# Altium schematic sheet SCH.SchDoc
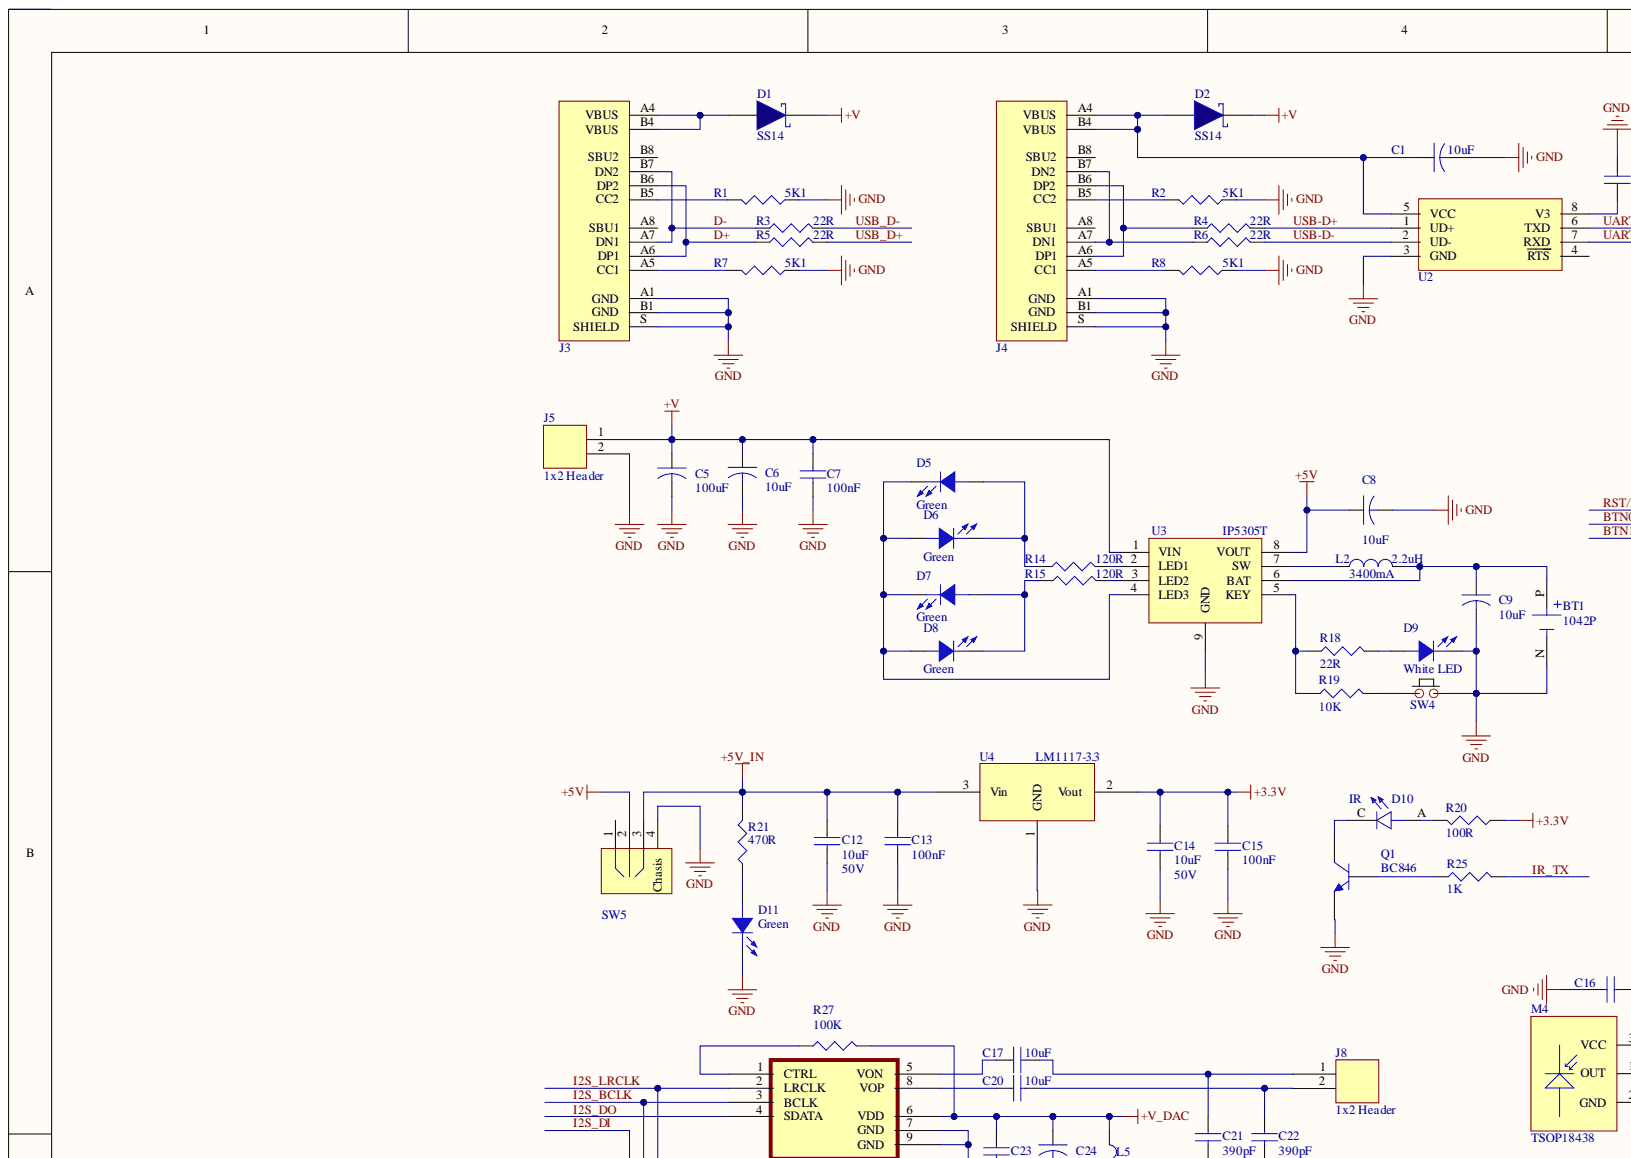
[diagram p0: SCH.SchDoc, top left]
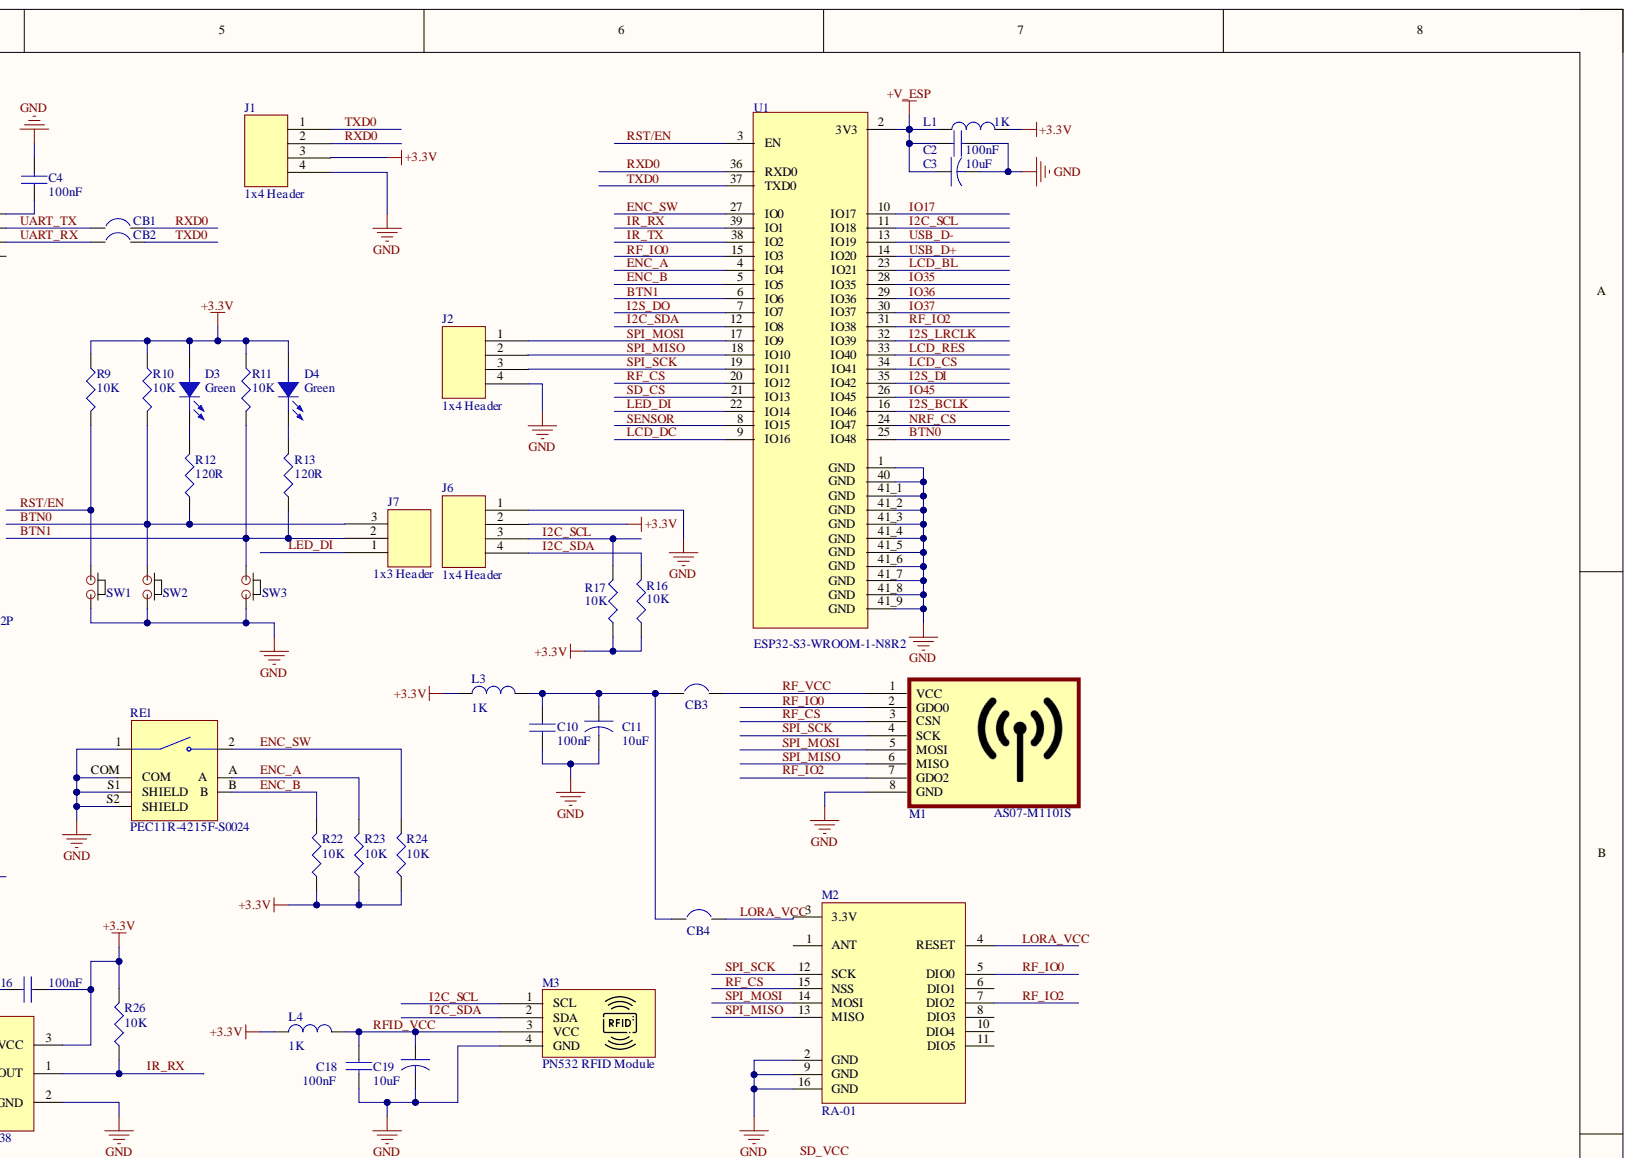
[diagram p1: SCH.SchDoc, top right]
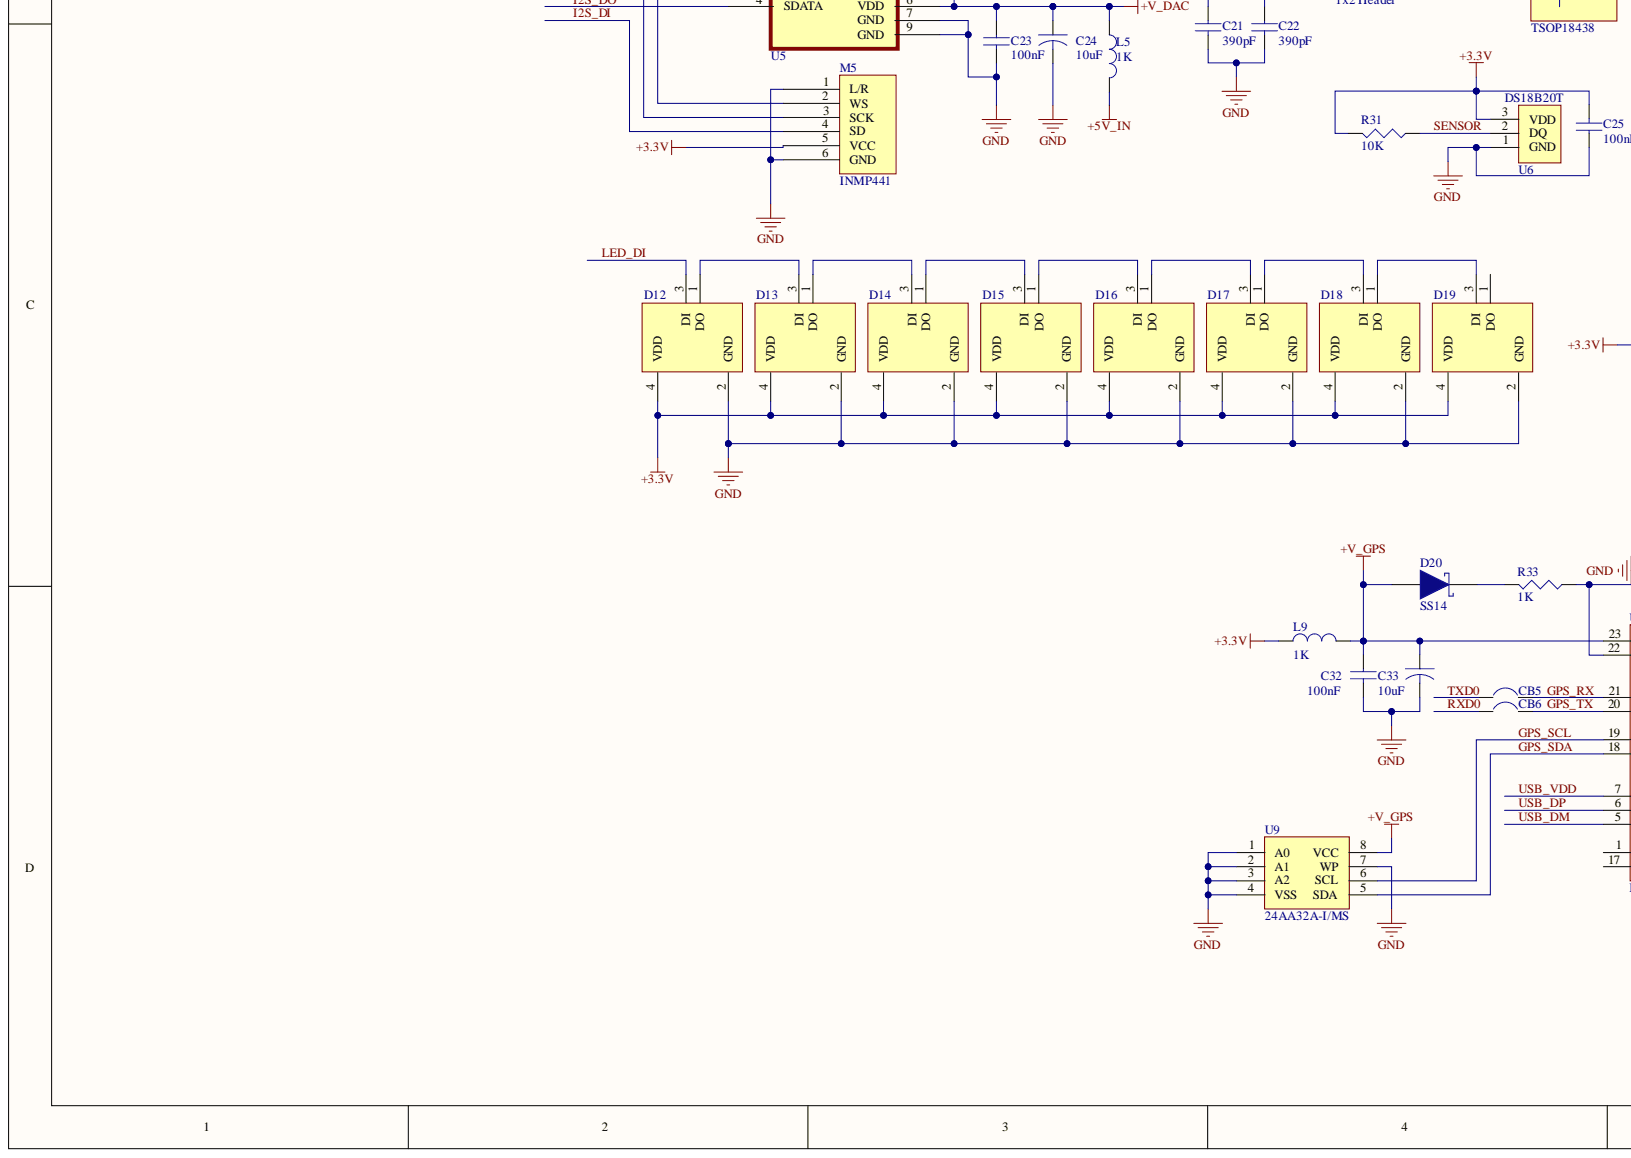
[diagram p2: SCH.SchDoc, bottom left]
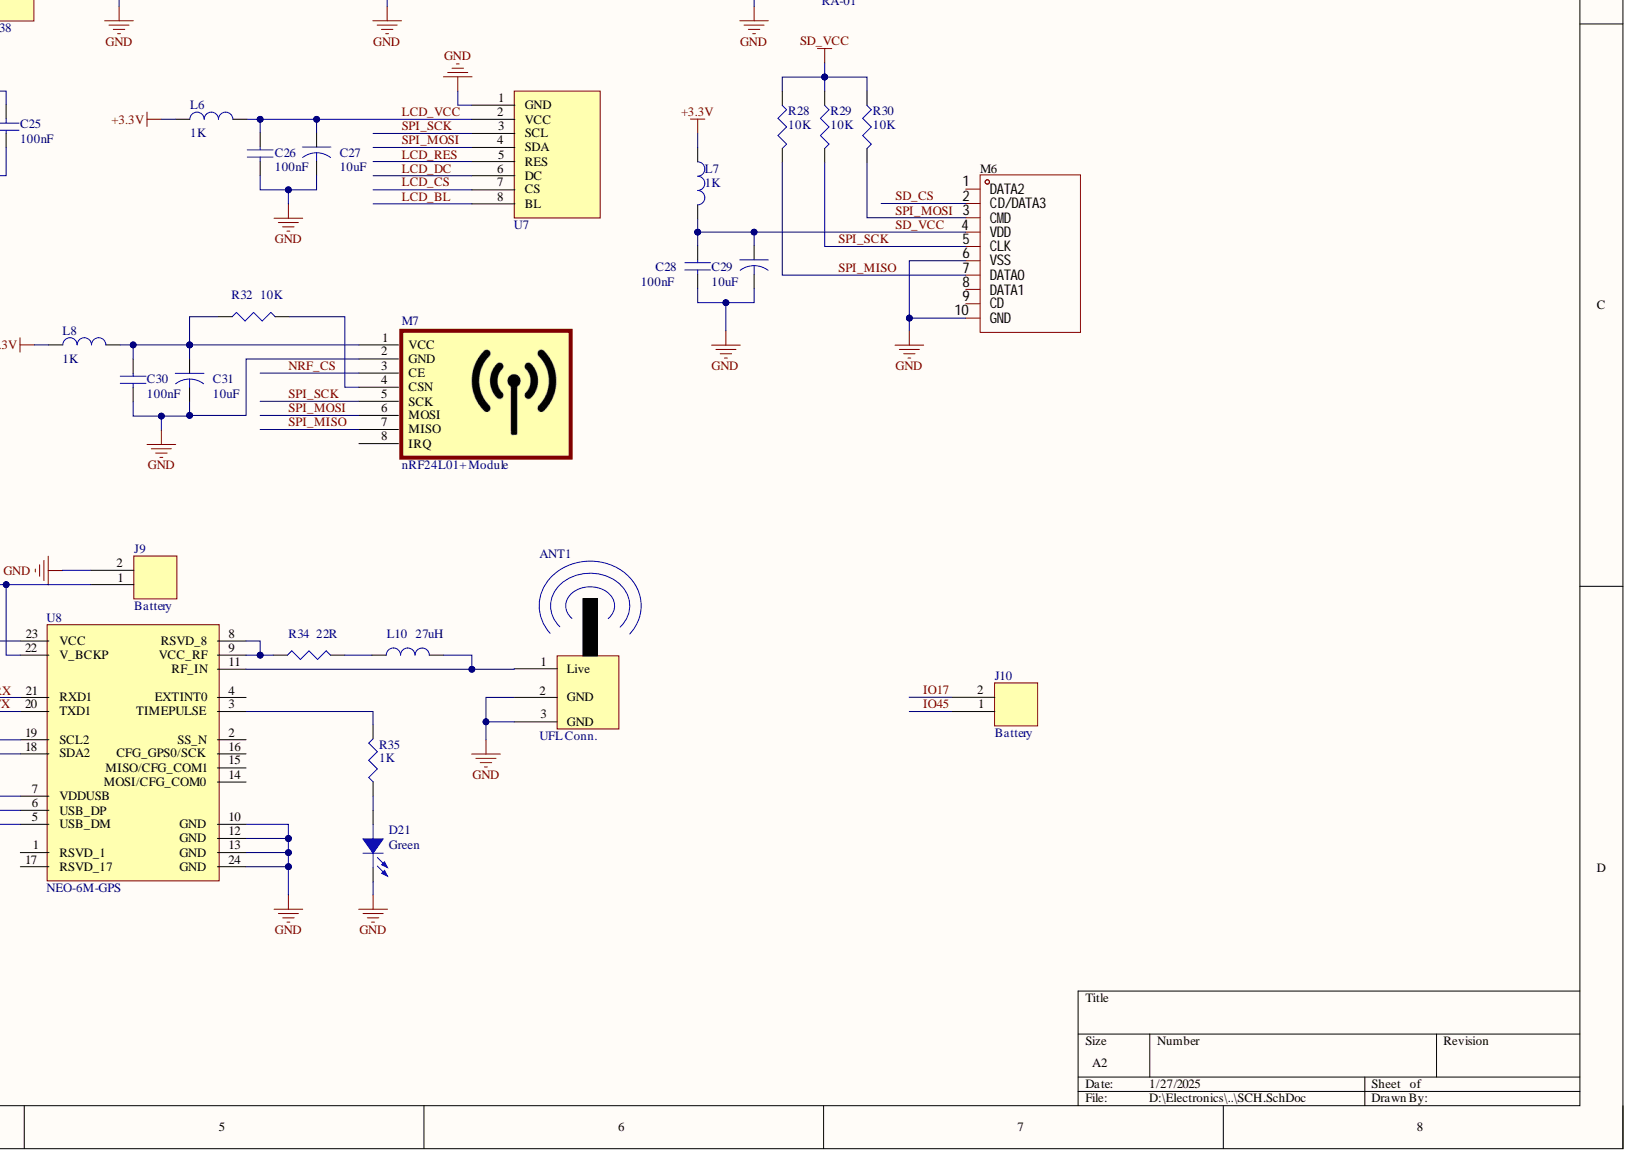
[diagram p3: SCH.SchDoc, bottom right]

## Parts
ANT1  value UFL Conn.  [UFL CONN]  (hidden comment: UFL Antenna)
BT1  1042P  [BAT_1042P_v2]
C1  value 10uF  [A Case]  (hidden comment: T491A106)
C2  value 100nF  [0805 SMD Ceramic Capacitor]  (hidden comment: C0805C104)
C3  value 10uF  [A Case]  (hidden comment: T491A106)
C4  value 100nF  [0805 SMD Ceramic Capacitor]  (hidden comment: C0805C104)
C5  value 100uF  [D Case]  (hidden comment: T491D107)
C6  value 10uF  [A Case]  (hidden comment: T491A106)
C7  value 100nF  [0805 SMD Ceramic Capacitor]  (hidden comment: C0805C104)
C8  value 10uF  [A Case]  (hidden comment: T491A106)
C9  value 10uF  [A Case]  (hidden comment: T491A106)
C10  value 100nF  [0805 SMD Ceramic Capacitor]  (hidden comment: C0805C104)
C11  value 10uF  [A Case]  (hidden comment: T491A106)
C12  value 10uF  Voltage: 50V  [1206 SMD Ceramic Capacitor]  (hidden comment: C1206C106)
C13  value 100nF  [0805 SMD Ceramic Capacitor]  (hidden comment: C0805C104)
C14  value 10uF  Voltage: 50V  [1206 SMD Ceramic Capacitor]  (hidden comment: C1206C106)
C15  value 100nF  [0805 SMD Ceramic Capacitor]  (hidden comment: C0805C104)
C16  value 100nF  [0805 SMD Ceramic Capacitor]  (hidden comment: C0805C104)
C17  value 10uF  [1206 SMD Ceramic Capacitor]  (hidden comment: C1206C106)
C18  value 100nF  [0805 SMD Ceramic Capacitor]  (hidden comment: C0805C104)
C19  value 10uF  [A Case]  (hidden comment: T491A106)
C20  value 10uF  [1206 SMD Ceramic Capacitor]  (hidden comment: C1206C106)
C21  value 390pF  [0805 SMD Ceramic Capacitor]  (hidden comment: C0805C391)
C22  value 390pF  [0805 SMD Ceramic Capacitor]  (hidden comment: C0805C391)
C23  value 100nF  [0805 SMD Ceramic Capacitor]  (hidden comment: C0805C104)
C24  value 10uF  [A Case]  (hidden comment: T491A106)
C25  value 100nF  [0805 SMD Ceramic Capacitor]  (hidden comment: C0805C104)
C26  value 100nF  [0805 SMD Ceramic Capacitor]  (hidden comment: C0805C104)
C27  value 10uF  [A Case]  (hidden comment: T491A106)
C28  value 100nF  [0805 SMD Ceramic Capacitor]  (hidden comment: C0805C104)
C29  value 10uF  [A Case]  (hidden comment: T491A106)
C30  value 100nF  [0805 SMD Ceramic Capacitor]  (hidden comment: C0805C104)
C31  value 10uF  [A Case]  (hidden comment: T491A106)
C32  value 100nF  [0805 SMD Ceramic Capacitor]  (hidden comment: C0805C104)
C33  value 10uF  [A Case]  (hidden comment: T491A106)
CB1  CircuitBraker  [CircuitBraker]  (value not drawn)
CB2  CircuitBraker  [CircuitBraker]  (value not drawn)
CB3  CircuitBraker  [CircuitBraker]  (value not drawn)
CB4  CircuitBraker  [CircuitBraker]  (value not drawn)
CB5  CircuitBraker  [CircuitBraker]  (value not drawn)
CB6  CircuitBraker  [CircuitBraker]  (value not drawn)
D1  SS14  [SMA]
D2  SS14  [SMA]
D3  value Green  [0805 Green LED]  (hidden comment: 0805 Green LED)
D4  value Green  [0805 Green LED]  (hidden comment: 0805 Green LED)
D5  value Green  [0805 Green LED]  (hidden comment: 0805 Green LED)
D6  value Green  [0805 Green LED]  (hidden comment: 0805 Green LED)
D7  value Green  [0805 Green LED]  (hidden comment: 0805 Green LED)
D8  value Green  [0805 Green LED]  (hidden comment: 0805 Green LED)
D9  value White LED  [5MM DIP WHITE LED]  (hidden comment: 5MM White LED)
D10  TSHG6200  [LEDRD254W55D595H900]  (value not drawn)
D11  value Green  [0805 Green LED]  (hidden comment: 0805 Green LED)
D12  WS2812C-2020  [LED_WS2812C-2020]  (value not drawn)
D13  WS2812C-2020  [LED_WS2812C-2020]  (value not drawn)
D14  WS2812C-2020  [LED_WS2812C-2020]  (value not drawn)
D15  WS2812C-2020  [LED_WS2812C-2020]  (value not drawn)
D16  WS2812C-2020  [LED_WS2812C-2020]  (value not drawn)
D17  WS2812C-2020  [LED_WS2812C-2020]  (value not drawn)
D18  WS2812C-2020  [LED_WS2812C-2020]  (value not drawn)
D19  WS2812C-2020  [LED_WS2812C-2020]  (value not drawn)
D20  SS14  [SMA]
D21  value Green  [0805 Green LED]  (hidden comment: 0805 Green LED)
J1  value 1x4 Header  [1x4 4 Pin Header]  (hidden comment: 1x4 4 Pin Header)
J2  value 1x4 Header  [1x4 4 Pin Header]  (hidden comment: 1x4 4 Pin Header)
J3  TYPE-C-31-M-12  [HRO_TYPE-C-31-M-12]  (value not drawn)
J4  TYPE-C-31-M-12  [HRO_TYPE-C-31-M-12]  (value not drawn)
J5  value 1x2 Header  [1x2 2 Pin Header]  (hidden comment: 1x2 2 Pin Header)
J6  value 1x4 Header  [1x4 4 Pin Header]  (hidden comment: 1x4 4 Pin Header)
J7  value 1x3 Header  [1x3 3 Pin Header]  (hidden comment: 1x3 3 Pin Header)
J8  value 1x2 Header  [1x2 2 Pin Header]  (hidden comment: 1x2 2 Pin Header)
J9  value Battery  [1x2 2 Pin Header]  (hidden comment: 1x2 2 Pin Header)
J10  value Battery  [1x2 2 Pin Header]  (hidden comment: 1x2 2 Pin Header)
L1  value 1K  [0805 Inductor]  (hidden comment: 0805Ferrite)
L2  2.2uH_3400mA  value 2.2uH  Current: 3400mA  [SMNR4020]
L3  value 1K  [0805 Inductor]  (hidden comment: 0805Ferrite)
L4  value 1K  [0805 Inductor]  (hidden comment: 0805Ferrite)
L5  value 1K  [0805 Inductor]  (hidden comment: 0805Ferrite)
L6  value 1K  [0805 Inductor]  (hidden comment: 0805Ferrite)
L7  value 1K  [0805 Inductor]  (hidden comment: 0805Ferrite)
L8  value 1K  [0805 Inductor]  (hidden comment: 0805Ferrite)
L9  value 1K  [0805 Inductor]  (hidden comment: 0805Ferrite)
L10  value 27uH  [0805 Inductor]  (hidden comment: 0805Ferrite)
M1  AS07-M1101S  [AS07-M1101S]
M2  RA-01  [XCVR_RA-01H]
M3  value PN532 RFID Module  [PN532 RFID Module]  (hidden comment: RC522 RFID Module)
M4  TSOP18438  [TSOP18438]
M5  INMP441  [INMP441]
M6  XKTF-N02-G  [TF-SMD_XKTF-N02-G]  (value not drawn)
M7  value nRF24L01+ Module  [NRF24L01_Module]  (hidden comment: NRF24L01_SMD)
Q1  BC846  [SOT23]
R1  value 5K1  [0805 Resistor]  (hidden comment: 0805_5K1%1)
R2  value 5K1  [0805 Resistor]  (hidden comment: 0805_5K1%1)
R3  value 22R  [0805 Resistor]  (hidden comment: 0805_22R%1)
R4  value 22R  [0805 Resistor]  (hidden comment: 0805_22R%1)
R5  value 22R  [0805 Resistor]  (hidden comment: 0805_22R%1)
R6  value 22R  [0805 Resistor]  (hidden comment: 0805_22R%1)
R7  value 5K1  [0805 Resistor]  (hidden comment: 0805_5K1%1)
R8  value 5K1  [0805 Resistor]  (hidden comment: 0805_5K1%1)
R9  value 10K  [0805 Resistor]  (hidden comment: 0805_10K)
R10  value 10K  [0805 Resistor]  (hidden comment: 0805_10K)
R11  value 10K  [0805 Resistor]  (hidden comment: 0805_10K)
R12  value 120R  [0805 Resistor]  (hidden comment: 0805_120R)
R13  value 120R  [0805 Resistor]  (hidden comment: 0805_120R)
R14  value 120R  [0805 Resistor]  (hidden comment: 0805_120R)
R15  value 120R  [0805 Resistor]  (hidden comment: 0805_120R)
R16  value 10K  [0805 Resistor]  (hidden comment: 0805_10K)
R17  value 10K  [0805 Resistor]  (hidden comment: 0805_10K)
R18  value 22R  [0805 Resistor]  (hidden comment: 0805_22R%1)
R19  value 10K  [0805 Resistor]  (hidden comment: 0805_10K)
R20  value 100R  [0805 Resistor]  (hidden comment: 0805_100R%1)
R21  value 470R  [0805 Resistor]  (hidden comment: 0805_470R%1)
R22  value 10K  [0805 Resistor]  (hidden comment: 0805_10K)
R23  value 10K  [0805 Resistor]  (hidden comment: 0805_10K)
R24  value 10K  [0805 Resistor]  (hidden comment: 0805_10K)
R25  value 1K  [0805 Resistor]  (hidden comment: 0805_1K)
R26  value 10K  [0805 Resistor]  (hidden comment: 0805_10K)
R27  value 100K  [0805 Resistor]  (hidden comment: 0805_100K%1)
R28  value 10K  [0805 Resistor]  (hidden comment: 0805_10K)
R29  value 10K  [0805 Resistor]  (hidden comment: 0805_10K)
R30  value 10K  [0805 Resistor]  (hidden comment: 0805_10K)
R31  value 10K  [0805 Resistor]  (hidden comment: 0805_10K)
R32  value 10K  [0805 Resistor]  (hidden comment: 0805_10K)
R33  value 1K  [0805 Resistor]  (hidden comment: 0805_10K)
R34  value 22R  [0805 Resistor]  (hidden comment: 0805_22R%1)
R35  value 1K  [0805 Resistor]  (hidden comment: 0805_10K)
RE1  PEC11R-4215F-S0024  [XDCR_PEC11R-4215F-S0024]
SW1  2.5mm Tactile Push Button  [2.5mm Tactile Push Button]  (value not drawn)
SW2  2.5mm Tactile Push Button  [2.5mm Tactile Push Button]  (value not drawn)
SW3  2.5mm Tactile Push Button  [2.5mm Tactile Push Button]  (value not drawn)
SW4  2.5mm Tactile Push Button  [2.5mm Tactile Push Button]  (value not drawn)
SW5  MSK12C02G15-B  [MSK12C02G15-B]  (value not drawn)
U1  ESP32-S3-WROOM-1-N8R2  [XCVR_ESP32-S3-WROOM-1-N8R2]
U2  CH340N  [SOIC127P600X160-8N]  (value not drawn)
U3  IP5305T  [DDA8]
U4  LM1117-3.3  [SOT223]
U5  NS4168  [DDA8]  (value not drawn)
U6  DS18B20  value DS18B20T  [TO92]
U7  ST7789_1.9_170x320  [ST7789_1.9_170x320]  (value not drawn)
U8  NEO-6M-GPS  [XCVR_NEO-6M-GPS]
U9  24AA32A-I/MS  [SOP65P490X110-8N]

## Nets
+3.3V: C14.1 C15.1 C16.1 C25.2 D3.1 D4.1 D12.4(VDD) D13.4(VDD) D14.4(VDD) D15.4(VDD) D16.4(VDD) D17.4(VDD) D18.4(VDD) D19.4(VDD) J1.3 J6.2 L1.2 L3.1 L4.1 L6.1 L7.1 L8.1 L9.1 M4.3 M5.5(VCC) R9.1 R10.1 R11.1 R16.1 R17.1 R20.1 R22.1 R23.1 R24.1 R26.2 R31.2 U4.2 U6.3
+5V: C8.1 SW5.2 U3.8(VOUT)
+5V_IN: C12.1 C13.1 L5.2 R21.2 SW5.3 U4.3
+V: C5.1 C6.1 C7.1 D1.2 D2.2 J5.1 U3.1(VIN)
+V_DAC: C23.1 C24.1 L5.1 R27.2 U5.6(VDD)
+V_ESP: C2.1 C3.1 L1.1 U1.2(3V3)
+V_GPS: C32.1 C33.1 D20.1 L9.2 U8.23(VCC) U9.8(VCC)
GND: ANT1.2 ANT1.3 BT1.N C1.2 C2.2 C3.2 C4.2 C5.2 C6.2 C7.2 C8.2 C9.2 C10.2 C11.2 C12.2 C13.2 C14.2 C15.2 C16.2 C18.2 C19.2 C21.2 C22.2 C23.2 C24.2 C25.1 C26.2 C27.2 C28.2 C29.2 C30.2 C31.2 C32.2 C33.2 D9.C D11.2 D12.2(GND) D13.2(GND) D14.2(GND) D15.2(GND) D16.2(GND) D17.2(GND) D18.2(GND) D19.2(GND) D21.2 J1.4 J2.4 J3.A1(GND) J3.B1(GND) J3.S(SHIELD) J4.A1(GND) J4.B1(GND) J4.S(SHIELD) J5.2 J6.1 J9.2 M1.8(GND) M2.2(GND) M2.9(GND) M2.16(GND) M3.4(GND) M4.2 M5.1(L/R) M5.6(GND) M6.6(VSS) M6.10(GND) M7.2(GND) Q1.2 R1.1 R2.1 R7.1 R8.1 RE1.1 RE1.COM RE1.S1(SHIELD) RE1.S2(SHIELD) SW1.2 SW2.2 SW3.2 SW4.2 SW5.4(Chasis) U1.1(GND) U1.40(GND) U1.41_1(GND) U1.41_2(GND) U1.41_3(GND) U1.41_4(GND) U1.41_5(GND) U1.41_6(GND) U1.41_7(GND) U1.41_8(GND) U1.41_9(GND) U2.3(GND) U3.9(GND) U4.1 U5.7(GND) U5.9(GND) U6.1 U7.1(GND) U8.10(GND) U8.12(GND) U8.13(GND) U8.24(GND) U9.1(A0) U9.2(A1) U9.3(A2) U9.4(VSS) U9.7(WP)
BTN0: J7.3 R10.2 R12.1 SW2.1 U1.25(IO48)
BTN1: J7.2 R11.2 R13.1 SW3.1 U1.6(IO6)
D+: J3.A6(DP1) J3.B6(DP2) R5.1
D-: J3.A7(DN1) J3.B7(DN2) R3.1
ENC_A: R23.2 RE1.A U1.4(IO4)
ENC_B: R22.2 RE1.B U1.5(IO5)
ENC_SW: R24.2 RE1.2 U1.27(IO0)
GPS_RX: CB5.1 U8.21(RXD1)
GPS_SCL: U8.19(SCL2) U9.6(SCL)
GPS_SDA: U8.18(SDA2) U9.5(SDA)
GPS_TX: CB6.1 U8.20(TXD1)
I2C_SCL: J6.3 M3.1(SCL) R17.2 U1.11(IO18)
I2C_SDA: J6.4 M3.2(SDA) R16.2 U1.12(IO8)
I2S_BCLK: M5.3(SCK) U1.16(IO46) U5.3(BCLK)
I2S_DI: M5.4(SD) U1.35(IO42)
I2S_DO: U1.7(IO7) U5.4(SDATA)
I2S_LRCLK: M5.2(WS) U1.32(IO39) U5.2(LRCLK)
IO17: J10.2 U1.10(IO17)
IO35: U1.28(IO35)
IO36: U1.29(IO36)
IO37: U1.30(IO37)
IO45: J10.1 U1.26(IO45)
IR_RX: M4.1 R26.1 U1.39(IO1)
IR_TX: R25.2 U1.38(IO2)
LCD_BL: U1.23(IO21) U7.8(BL)
LCD_CS: U1.34(IO41) U7.7(CS)
LCD_DC: U1.9(IO16) U7.6(DC)
LCD_RES: U1.33(IO40) U7.5(RES)
LCD_VCC: C26.1 C27.1 L6.2 U7.2(VCC)
LED_DI: D12.3(DI) J7.1 U1.22(IO14)
LORA_VCC: CB4.2 M2.3(3.3V) M2.4(RESET)
NRF_CS: M7.3(CE) U1.24(IO47)
RF_CS: M1.3(CSN) M2.15(NSS) U1.20(IO12)
RF_IO0: M1.2(GDO0) M2.5(DIO0) U1.15(IO3)
RF_IO2: M1.7(GDO2) M2.7(DIO2) U1.31(IO38)
RF_VCC: CB3.2 M1.1(VCC)
RFID_VCC: C18.1 C19.1 L4.2 M3.3(VCC)
RST/EN: R9.2 SW1.1 U1.3(EN)
RXD0: CB1.2 CB6.2 J1.2 U1.36(RXD0)
SD_CS: M6.2(CD/DATA3) U1.21(IO13)
SD_VCC: C28.1 C29.1 L7.2 M6.4(VDD) R28.1 R29.1 R30.1
SENSOR: R31.1 U1.8(IO15) U6.2
SPI_MISO: J2.2 M1.6(MISO) M2.13(MISO) M6.7(DATA0) M7.7(MISO) R28.2 U1.18(IO10)
SPI_MOSI: J2.1 M1.5(MOSI) M2.14(MOSI) M6.3(CMD) M7.6(MOSI) R30.2 U1.17(IO9) U7.4(SDA)
SPI_SCK: J2.3 M1.4(SCK) M2.12(SCK) M6.5(CLK) M7.5(SCK) R29.2 U1.19(IO11) U7.3(SCL)
TXD0: CB2.2 CB5.2 J1.1 U1.37(TXD0)
UART_RX: CB2.1 U2.7(RXD)
UART_TX: CB1.1 U2.6(TXD)
USB-D+: R4.2 U2.1(UD+)
USB-D-: R6.2 U2.2(UD-)
USB_D+: R5.2 U1.14(IO20)
USB_D-: R3.2 U1.13(IO19)
USB_DM: U8.5(USB_DM)
USB_DP: U8.6(USB_DP)
USB_VDD: U8.7(VDDUSB)
NetANT1_1: ANT1.1 L10.2 U8.11(RF_IN)
NetBT1_P: BT1.P C9.1 L2.2 U3.6(BAT)
NetC1_1: C1.1 D2.1 J4.A4(VBUS) J4.B4(VBUS) U2.5(VCC)
NetC4_1: C4.1 U2.8(V3)
NetC10_1: C10.1 C11.1 CB3.1 CB4.1 L3.2
NetC17_1: C17.1 U5.5(VON)
NetC17_2: C17.2 C21.1 J8.1
NetC20_1: C20.1 U5.8(VOP)
NetC20_2: C20.2 C22.1 J8.2
NetC30_1: C30.1 C31.1 L8.2 M7.1(VCC) R32.1
NetD1_1: D1.1 J3.A4(VBUS) J3.B4(VBUS)
NetD3_2: D3.2 R12.2
NetD4_2: D4.2 R13.2
NetD5_1: D5.1 D6.2 R14.1
NetD5_2: D5.2 D6.1 D7.2 D8.1 U3.4(LED3)
NetD7_1: D7.1 D8.2 R15.1
NetD9_A: D9.A R18.2
NetD10_A: D10.A R20.2
NetD10_C: D10.C Q1.3
NetD11_1: D11.1 R21.1
NetD12_1: D12.1(DO) D13.3(DI)
NetD13_1: D13.1(DO) D14.3(DI)
NetD14_1: D14.1(DO) D15.3(DI)
NetD15_1: D15.1(DO) D16.3(DI)
NetD16_1: D16.1(DO) D17.3(DI)
NetD17_1: D17.1(DO) D18.3(DI)
NetD18_1: D18.1(DO) D19.3(DI)
NetD20_2: D20.2 R33.2
NetD21_1: D21.1 R35.1
NetJ3_A5: J3.A5(CC1) R7.2
NetJ3_B5: J3.B5(CC2) R1.2
NetJ4_A5: J4.A5(CC1) R8.2
NetJ4_A6: J4.A6(DP1) J4.B6(DP2) R4.1
NetJ4_A7: J4.A7(DN1) J4.B7(DN2) R6.1
NetJ4_B5: J4.B5(CC2) R2.2
NetJ9_1: J9.1 R33.1 U8.22(V_BCKP)
NetL2_1: L2.1 U3.7(SW)
NetL10_1: L10.1 R34.2
NetM7_4: M7.4(CSN) R32.2
NetQ1_1: Q1.1 R25.1
NetR14_2: R14.2 U3.2(LED1)
NetR15_2: R15.2 U3.3(LED2)
NetR18_1: R18.1 R19.1 U3.5(KEY)
NetR19_2: R19.2 SW4.1
NetR27_1: R27.1 U5.1(CTRL)
NetR34_1: R34.1 U8.8(RSVD_8) U8.9(VCC_RF)
NetR35_2: R35.2 U8.3(TIMEPULSE)

Not connected: D19 1(DO); J3 A8(SBU1) B8(SBU2); J4 A8(SBU1) B8(SBU2); M2 1(ANT) 6(DIO1) 8(DIO3) 10(DIO4) 11(DIO5); M6 1(DATA2) 8(DATA1) 9(CD); M7 8(IRQ); SW5 1; U2 4(RTS); U8 1(RSVD_1) 2(SS_N) 4(EXTINT0) 14(MOSI/CFG_COM0) 15(MISO/CFG_COM1) 16(CFG_GPS0/SCK) 17(RSVD_17)

## Library data of the parts
ANT1 = UFL Antenna: Manufacturer: HIROSE; Manufacturer Code: U.FL-R-SMT-1(10); Component Kind: Standard; Farnell Code: 1688077; Library Name: UFL_CONN.SCHLIB; MOQ: 1; Unit Price: 0,578 €
BT1 = 1042P: SMT Polarized Holder for 18650 Battery; MF: Keystone Electronics; Availability: In Stock; Check_prices: https://www.snapeda.com/parts/1042P/Keystone+Electronics/view-part/?ref=eda; Component Kind: Standard; Library Name: 18650_BatterHolder_1042P.IntLib; MP: 1042P; Package: None; Price: None; Purchase-URL: https://pricing.snapeda.com/search/part/1042P/?ref=eda; SnapEDA_Link: https://www.snapeda.com/parts/1042P/Keystone+Electronics/view-part/?ref=snap
C1 C3 C6 C8 C9 C11 C19 C24 C27 C29 C31 C33 = T491A106: Manufacturer: KEMET; Manufacturer Code: T491A106K006AT; Voltage: 6V3; Component Kind: Standard; Farnell Code: 1457412; Library Name: Capacitors.SchLib; Unit Price: 0,143 €
C2 C4 C7 C10 C13 C15 C16 C18 C23 C25 C26 C28 C30 C32 = C0805C104: Manufacturer: KEMET; Manufacturer Code: C0805C104J5RACTU; Voltage: 50V; Component Kind: Standard; Farnell Code: 1414663; Library Name: Capacitors.SchLib; Package: 0805; Unit Price: 0,06 €
C5 = T491D107: Manufacturer: KEMET; Manufacturer Code: T491D107K010AT; Voltage: 10V; Component Kind: Standard; Farnell Code: 1457492; Library Name: Capacitors.SchLib; Unit Price: 0,47 €
C12 C14 = C1206C106: Manufacturer: MULTICOMP; Manufacturer Code: MC1206B475K500CT; Capacitance Tolerance: ±10%; Component Kind: Standard; Farnell Code: 2320908; Library Name: Capacitors.SchLib; MOQ: 10; Unit Price: 0,644 €
C17 C20 = C1206C106: Manufacturer: MULTICOMP; Manufacturer Code: MC1206B475K500CT; Capacitance Tolerance: ±10%; Voltage: 50V; Component Kind: Standard; Farnell Code: 2320908; Library Name: Capacitors.SchLib; MOQ: 10; Unit Price: 0,644 €
C21 C22 = C0805C391: Manufacturer: MULTICOMP; Manufacturer Code: C0805C391J5GACTU; Voltage: 50V; Component Kind: Standard; Farnell Code: 1163547; Library Name: Capacitors.SchLib; Package: 0805; Unit Price: 0,17 €
D1 D2 D20 = SS14: Manufacturer: onsemi; Forward Current: 1A; Voltage: 40V; Component Kind: Standard; Digikey Code: SS14TR-ND; Library Name: SS14.SchLib; Manufacturer Code: SS14; Unit Price: 0.29 $; Value: SS14
D3-D8 D11 D21 = 0805 Green LED: Manufacturer: AVAGO Technologies; Manufacturer Code: HSMG-C170; Current: 20mA; Voltage: 2.2V; Component Kind: Standard; Farnell Code: 5790852; Library Name: LED.SchLib; Unit Price: 0,134 €
D9 = 5MM White LED: LED 5MM WHITE 450-700mcd 7500-9000K 3V THT; Manufacturer: HONEST; Manufacturer Code: HL-LVC505WC-MA-N; Voltage: 3.00 V; Component Kind: Standard; Current: -; Library Name: LED.SchLib; MOQ: 2; Price Unit: $; Unit Price: 0.02930
D10 = TSHG6200: Infrared Emitter 850nm 1800mW/sr Circular Top Mount 2-Pin T-1 3/4; Manufacturer: Vishay; Component Kind: Standard; Digikey Code: 751-1212-ND; Library Name: TSHG6200.IntLib; Manufacturer Code: TSHG6200; MP: TSHG6200; Price: 1.56 $; Value: TSHG6200
D12-D19 = WS2812C-2020: SMD,2x2mm RGB LEDs(Built-in IC) ROHS; Manufacturer: Worldsemi; Manufacturer Code: WS2812C-2020-V1; Component Kind: Standard; Library Name: WS2812C-2020.SchLib; MOQ: 5; Price: 0.069 $; Value: WS2812C
J3 J4 = TYPE-C-31-M-12: USB Type C Connector; Manufacturer: HRO Electronics Co., Ltd.; Component Kind: Standard; Library Name: TYPE-C-31-M-12.SchLib; Manufacturer Code: TYPE-C-31-M-12; Unit Price: 0.0438
L1 L3-L10 = 0805Ferrite: Manufacturer: TDK; Manufacturer Code: MMZ2012R102A; Current: 500mA; Component Kind: Standard; Farnell Code: 1669719; Library Name: FerriteBead.SchLib; Unit Price: 0,059 €
L2 = 2.2uH_3400mA: Manufacturer: SXN(Shun Xiang Nuo Elec); Manufacturer Code: SMNR4020-2.2UH; Component Kind: Standard; Library Name: Inductors.SchLib; MOQ: 10; Unit Price: 0.0473 $
M1 = AS07-M1101S: CC1101 Module; Component Kind: Standard; Library Name: AS07-M1101S.SchLib; Value: AS07-M1101S
M2 = RA-01: General ISM < 1GHz LoRa™ Transceiver Module 410MHz ~ 525MHz Antenna Not Included Surface Mount; Manufacturer: AI-Thinker; Component Kind: Standard; Digikey Code: 4570-RA-01TR-ND; Library Name: RA-01.IntLib; Manufacturer Code: RA-01; Unit Price: 5.3155 $; Value: RA-01
M3 = RC522 RFID Module: PN532 RFID Module; Component Kind: Standard; Library Name: PN532_RFID_Module.IntLib; Unit Price: 2.00 $
M4 = TSOP18438: IR Remote Receiver 38KHz 35m 3-Pin; Manufacturer: Vishay; Component Kind: Standard; Digikey Code: TSOP18438-ND; Library Name: TSOP18438.IntLib; Manufacturer Code: TSOP18438; Unit Price: 0.78 $; Value: TSOP18438
M5 = INMP441: I2S Interfaced Mems Microphone Module; Component Kind: Standard; Library Name: INMP441.SchLib; Unit Price: 1.3 $; Value: INMP441
M6 = XKTF-N02-G: Manufacturer: XKB Connection; Value: uSD Card; Component Kind: Standard; Library Name: XKTF-N02-G.IntLib; Manufacturer Part: XKTF-N02-G; Unit Price: 0.1025 $
M7 = NRF24L01_SMD: Manufacturer: Nordic Semiconductor; Manufacturer Code: NRF24L01+SMD; Component Kind: Standard; Library Name: NRF24L01_Module.SchLib; Unit Price: 3$
Q1 = BC846: Manufacturer: NXP Semiconductors; Voltage: 65V; Component Kind: Standard; Farnell Code: 1081227; Library Name: BC846.SchLib; Manufacturer Code: BC846; Unit Price: 0,049 €; Value: BC846
R1 R2 R7 R8 = 0805_5K1%1: 5.1 kOhms ±5% 0.125W, 1/8W Chip Resistor 0805 (2012 Metric) Moisture Resistant Thick Film; Manufacturer: YAGEO; Manufacturer Code: RC0805JR-075K1L; Component Kind: Standard; Digikey Code: 1469942; Library Name: Resistors.SchLib; Tolerance: ±5%; Unit Price: 0,01 $
R3-R6 R18 R34 = 0805_22R%1: Manufacturer: MULTICOMP; Manufacturer Code: MC01W0805122R; Tolerance: ±1%; Component Kind: Standard; Farnell Code: 9332847; Library Name: Resistors.SchLib; MOQ: 10; Unit Price: 0,02 €
R9-R11 R16 R17 R19 R22-R24 R26 R28-R32 = 0805_10K: Manufacturer: MULTICOMP; Manufacturer Code: MC 0.1W 0805 1% 10K; Tolerance: ±1%; Component Kind: Standard; Farnell Code: 9332391; Library Name: Resistors.SchLib; Unit Price: 0,03 €
R12-R15 = 0805_120R: Manufacturer: MULTICOMP; Manufacturer Code: MC 0.1W 0805 1% 120R; Tolerance: ±1%; Component Kind: Standard; Farnell Code: 9332480; Library Name: Resistors.SchLib; Unit Price: 0,03 €
R20 = 0805_100R%1: Manufacturer: MULTICOMP; Manufacturer Code: MC 0.1W 0805 1% 100R; Tolerance: ±1%; Component Kind: Standard; Farnell Code: 9332375; Library Name: Resistors.SchLib; Unit Price: 0,03 €
R21 = 0805_470R%1: Manufacturer: VISHAY DRALORIC; Manufacturer Code: CRCW0805470RFKEA; Power Rating: 125mW; Temperature Coefficient: ±100ppm/°C; Tolerance: ±1%; Component Kind: Standard; Farnell Code: 1469932; Library Name: Resistors.SchLib; Unit Price: 0,027 €
R25 R33 R35 = 0805_1K: Manufacturer: MULTICOMP; Manufacturer Code: MC01W080511K; Tolerance: ±1%; Component Kind: Standard; Farnell Code: 9332383; Library Name: Resistors.SchLib; Unit Price: 0,03 €
R27 = 0805_100K%1: Manufacturer: MULTICOMP; Manufacturer Code: MC01W08051100K; Tolerance: ±1%; Component Kind: Standard; Farnell Code: 9332405; Library Name: Resistors.SchLib; Unit Price: 0,03 €
RE1 = PEC11R-4215F-S0024: Incremental Encoder, 12 Mm, Contact Rating 10 Ma - 5 Vdc; Availability: Unavailable; Component Kind: Standard; Library Name: PEC11R-4215F-S0024.IntLib; MP: PEC11R-4215F-S0024; Package: None; Price: None
SW5 = MSK12C02G15-B: SMD-7P,6.7x2.8mm Slide Switches ROHS; Manufacturer: HanElectricity; Value: Switch; Component Kind: Standard; Library Name: Switch_MSK12C02G15-B.SchLib; Manufacturer Code: MSK12C02G15-B; MOQ: 20; Unit Price: 0.0234 $
U1 = ESP32-S3-WROOM-1-N8R2: WiFi 802.11a/b/g/n, Bluetooth v5.0 Transceiver Module 2.4GHz Antenna Not Included -; Manufacturer: Espressif Systems; Component Kind: Standard; Digikey Code: ESP32-S3-WROOM-1-N8R2-ND; Library Name: ESP32-S3-WROOM-1-N8R2.SchLib; Manufacturer Code: ESP32-S3-WROOM-1-N8R2; Unit Price: 3.35 $; Value: ESP32-S3
U2 = CH340N: USB to Serial Port Chip; Manufacturer: WCH; Component Kind: Standard; Library Name: CH340N.IntLib; Manufacturer Code: CH340N; Package: SO8; Price Unit: $; Unit Price: 0.67183; Value: CH340N
U3 = IP5305T: Fully-integrated power bank System-On-Chip with  1.2A charger, 1.0A boost converter; Manufacturer: INJOINIC; Component Kind: Standard; Library Name: IP5305T.SchLib; Manufacturer Code: IP5305T; Unit Price: 0.1849 $; Value: IP5305T
U4 = LM1117-3.3: Manufacturer: Texas Instruments; Manufacturer Code: LM1117MP-3.3; Current: 800mA; Voltage: 3.3V; Component Kind: Standard; Farnell Code: 9778195; Library Name: LM1117.SchLib; Unit Price: 1,14 €; Value: LM1117-3.3
U5 = NS4168: 2.5Wx1@4Ω Class D Amplifier ESOP-8-EP(3.9x4.9x1.27) Audio Amplifiers ROHS; Manufacturer: Shenzhen Nsiway Tech; Component Kind: Standard; Library Name: NS4168.SchLib; Manufacturer Code: NS4168; Unit Price: 0.598 $; Value: NS4168
U6 = DS18B20: Temperature Control and Regulation, Temperature Sensing/Measurement, Industrial Control, Sensors (Acceleration, Press...; Manufacturer: XBLW; Manufacturer Code: DS18B20T(XBLW); Component Kind: Standard; Library Name: DS18B20.IntLib; Package: TO-226-3 Maxim; Unit Price: 0.2565 $
U7 = ST7789_1.9_170x320: ST7789 1.9" TFT IPS 170x320; Component Kind: Standard; Library Name: ST7789_19_170x320.SCHLIB; Unit Price: 4 $; Value: ST7789
U8 = NEO-6M-GPS: GPS receivers featuring the high-performance u-blox 6 positioning engine; Manufacturer Code: NEO-6M-0-001; Value: NEO-6M; Component Kind: Standard; Library Name: NEO-6M-GPS.IntLib; Manufacturer: u-blox; Price: 4; Price Unit: $
U9 = 24AA32A-I/MS: EEPROM Memory IC 32Kb _4K x 8_ IÂ²C 400kHz 900ns 8-MSOP; Manufacturer: Microchip; Component Kind: Standard; Library Name: 24AA32A-I_MS.IntLib; Manufacturer Code: 24AA32A-I/MS; Package: MSOP-8; Price: 0.54; Price Unit: $; Value: 24AA32A-I/MS
CB1-CB6 = CircuitBraker: Component Kind: Standard; Description: CircuitBraker; Library Name: CircuitBraker.IntLib
J1 J2 J6 = 1x4 4 Pin Header: Component Kind: Standard; Library Name: Header.SchLib
J5 J8-J10 = 1x2 2 Pin Header: Component Kind: Standard; Library Name: Header.SchLib
J7 = 1x3 3 Pin Header: Component Kind: Standard; Library Name: Header.SchLib
SW1-SW4 = 2.5mm Tactile Push Button: Component Kind: Standard; Library Name: ButtonSwitch.SchLib; Value: 2.5mm Tactile Push Button
